# Altium schematic sheet XENON_CTRL.SchDoc
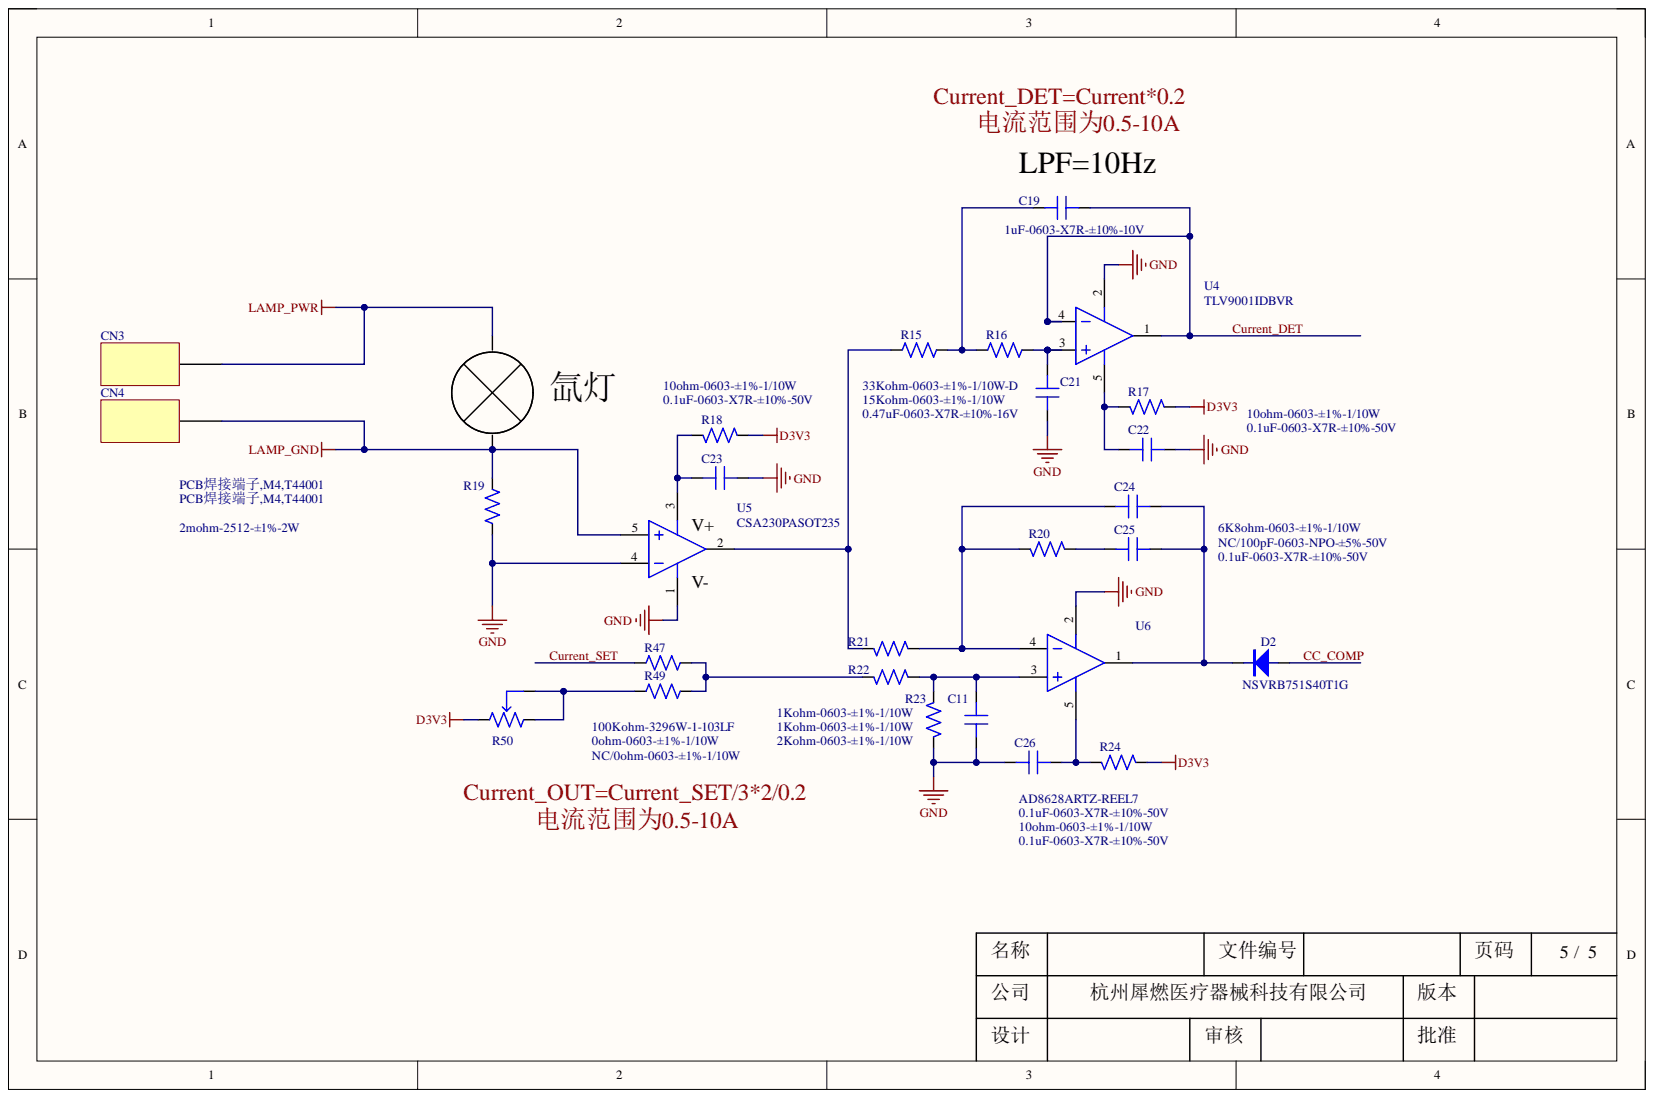
[diagram p0: XENON_CTRL.SchDoc]

## Parts
C11  0.1uF-0603-X7R-±10%-50V  [C0603]
C19  1uF-0603-X7R-±10%-10V  [C0603]
C21  0.47uF-0603-X7R-±10%-16V  [C0603]
C22  0.1uF-0603-X7R-±10%-50V  [C0603]
C23  0.1uF-0603-X7R-±10%-50V  [C0603]
C24  NC/100pF-0603-NPO-±5%-50V  [C0603]
C25  0.1uF-0603-X7R-±10%-50V  [C0603]
C26  0.1uF-0603-X7R-±10%-50V  [C0603]
CN3  PCB焊接端子,M4,T44001  [PCB焊接端子,M4,T44001]
CN4  PCB焊接端子,M4,T44001  [PCB焊接端子,M4,T44001]
D2  NSVRB751S40T1G  [DIODE-S-8]
R15  33Kohm-0603-±1%-1/10W-D  [R0603]
R16  15Kohm-0603-±1%-1/10W  [R0603]
R17  10ohm-0603-±1%-1/10W  [R0603]
R18  10ohm-0603-±1%-1/10W  [R0603]
R19  2mohm-2512-±1%-2W  [R2512]
R20  6K8ohm-0603-±1%-1/10W  [R0603]
R21  1Kohm-0603-±1%-1/10W  [R0603]
R22  1Kohm-0603-±1%-1/10W  [R0603]
R23  2Kohm-0603-±1%-1/10W  [R0603]
R24  10ohm-0603-±1%-1/10W  [R0603]
R47  0ohm-0603-±1%-1/10W  [R0603]
R49  NC/0ohm-0603-±1%-1/10W  [R0603]
R50  100Kohm-3296W-1-103LF  [RW3]
U4  TLV9001IDBVR  [SOT-23-5]
U5  CSA230PASOT235  [SOT-23-5]
U6  AD8628ARTZ-REEL7  [SOT-23-5]

## Nets
D3V3: R17.1 R18.1 R24.2 R50.1
GND: C11.1 C21.1 C22.1 C23.1 C26.1 R19.1 R23.2 U4.2 U5.1 U5.4 U6.2
LAMP_GND: CN4.1 R19.2 U5.5
LAMP_PWR: CN3.1
CC_COMP: D2.A
Current_DET: C19.2 U4.1 U4.4
Current_SET: R47.1
NetC11_2: C11.2 R22.2 R23.1 U6.3
NetC19_1: C19.1 R15.2 R16.1
NetC21_2: C21.2 R16.2 U4.3
NetC22_2: C22.2 R17.2 U4.5
NetC23_2: C23.2 R18.2 U5.3
NetC24_1: C24.1 R20.2 R21.2 U6.4
NetC24_2: C24.2 C25.2 D2.K U6.1
NetC25_1: C25.1 R20.1
NetC26_2: C26.2 R24.1 U6.5
NetR15_1: R15.1 R21.1 U5.2
NetR22_1: R22.1 R47.2 R49.2
NetR49_1: R49.1 R50.2 R50.3

## Library data of the parts
C11 C22 C23 C25 C26 = 0.1uF-0603-X7R-±10%-50V-D: CAP; Component Kind: Standard; Library Name: RCL.SchLib
C19 = 1uF-0603-X7R-±10%-10V-D: Component Kind: Standard; Library Name: RCL.SchLib
C21 = 0.47uF-0603-X7R-±10%-16V-D: Component Kind: Standard; Library Name: RCL.SchLib
C24 = 100pF-0603-NPO-±5%-50V-D: Component Kind: Standard; Library Name: RCL.SchLib
CN3 CN4 = PCB焊接端子,M4,T44001-DHMI: Component Kind: Standard; Library Name: Connector.SchLib
D2 = NSVRB751S40T1G-SBD-D: Schottky Barrier Diode,ONSEMI,30V,30mA,0.28V; Component Kind: Standard; Library Name: Semiconductor.SchLib
R16 = 15Kohm-0603-±1%-1/10W-D: Component Kind: Standard; Library Name: RCL.SchLib
R17 R18 R24 = 10ohm-0603-±1%-1/10W-D: Component Kind: Standard; Library Name: RCL.SchLib
R19 = 2mohm-2512-±1%-2W-D: Component Kind: Standard; Library Name: RCL.SchLib
R20 = 6K8ohm-0603-±1%-1/10W-D: Component Kind: Standard; Library Name: RCL.SchLib
R21 R22 = 1Kohm-0603-±1%-1/10W-D: Component Kind: Standard; Library Name: RCL.SchLib
R23 = 2Kohm-0603-±1%-1/10W-D: Component Kind: Standard; Library Name: RCL.SchLib
R47 R49 = 0ohm-0603-±1%-1/10W-D: Component Kind: Standard; Library Name: RCL.SchLib
R50 = 100Kohm-VR-3296-±10%-1/10W-D: Component Kind: Standard; Library Name: RCL.SchLib
U4 = TLV9001IDBVR-D: Component Kind: Standard; Library Name: Semiconductor.SchLib
U5 = CSA230PASOT235-D: Current AMP,100V/A,0-76V; Component Kind: Standard; Library Name: Semiconductor.SchLib
U6 = AD8628ARTZ-REEL7-D: Component Kind: Standard; Library Name: Semiconductor.SchLib
R15 = 33Kohm-0603-±1%-1/10W-D: Component Kind: Standard; Library Name: RCL.SchLib
